# Altium schematic sheet Heracles Headers.SchDoc
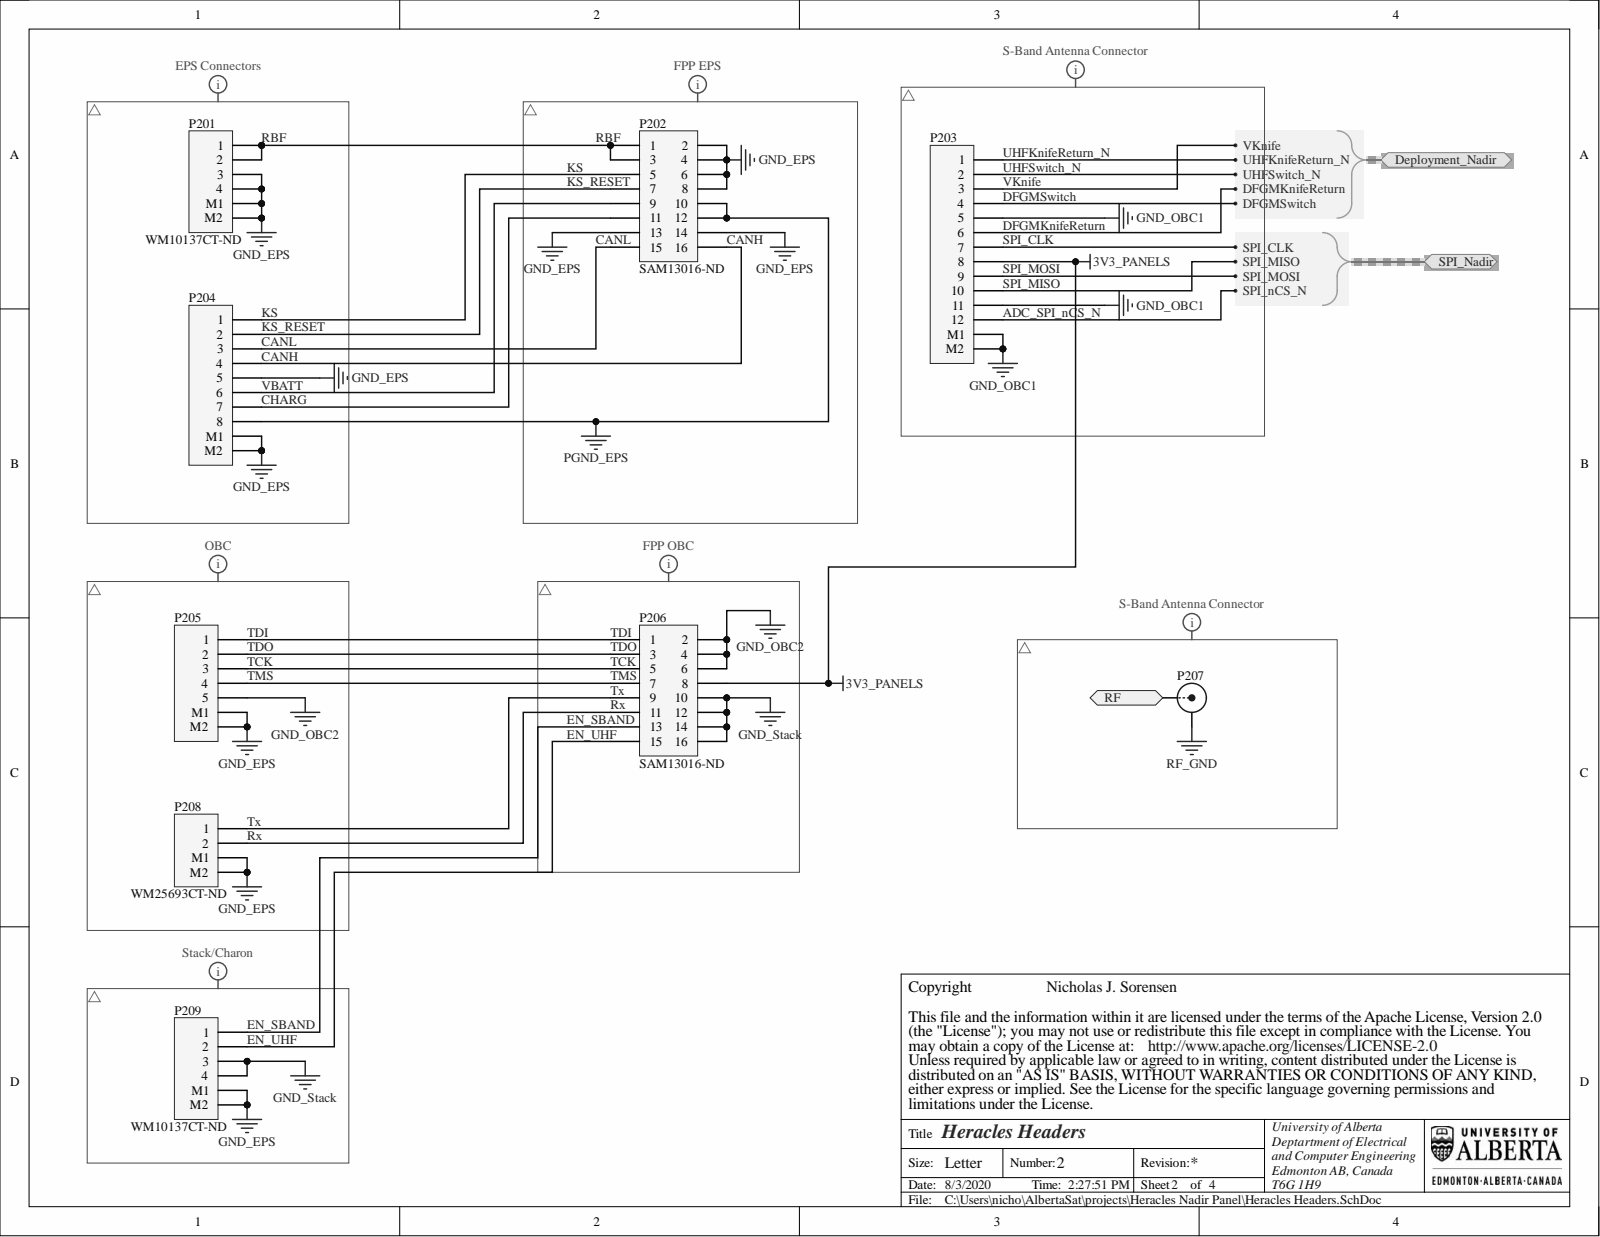
[diagram p0: Heracles Headers.SchDoc]

## Parts
P201  WM10137CT-ND  [MOLX-5040500491]
P202  SAM13016-ND  [TSS-108-01-G-D]
P203  PICOLOCK 12  [MOLX-5040501291]  (value not drawn)
P204  WM14421CT-ND  [MOLX-5040500891]  (value not drawn)
P205  PICOLOCK 5  [MOLX-5040500591]  (value not drawn)
P206  SAM13016-ND  [TSS-108-01-G-D]
P207  J10399-ND  [J10399-ND]  (value not drawn)
P208  WM25693CT-ND  [MOLX-5040500291]
P209  WM10137CT-ND  [MOLX-5040500491]

## Ports
Deployment_Nadir, Deployment_Nadir.DFGMKnifeReturn, Deployment_Nadir.DFGMSwitch, Deployment_Nadir.UHFKnifeReturn_N, Deployment_Nadir.UHFSwitch_N, Deployment_Nadir.VKnife, RF, SPI_Nadir, SPI_Nadir.SPI_CLK, SPI_Nadir.SPI_MISO, SPI_Nadir.SPI_MOSI, SPI_Nadir.SPI_nCS_N

## Nets
3V3_PANELS: P203.8 P206.8
GND_EPS: P201.3 P201.4 P201.M1 P201.M2 P202.2 P202.4 P202.6 P202.8 P202.13 P202.14 P204.5 P204.M1 P204.M2 P205.M1 P205.M2 P208.M1 P208.M2 P209.M1 P209.M2
GND_OBC1: P203.5 P203.11 P203.M1 P203.M2
GND_OBC2: P205.5 P206.2 P206.4 P206.6
GND_Stack: P206.10 P206.12 P206.14 P206.16 P209.3 P209.4
PGND_EPS: P202.10 P202.12 P204.8
RF_GND: P207.2
ADC_SPI_nCS_N: P203.12 ; port SPI_Nadir
CANH: P202.16 P204.4
CANL: P202.15 P204.3
CHARG: P202.11 P204.7
DFGMKnifeReturn: P203.6 ; port Deployment_Nadir
DFGMSwitch: P203.4 ; port Deployment_Nadir
EN_SBAND: P206.13 P209.1
EN_UHF: P206.15 P209.2
KS: P202.5 P204.1
KS_RESET: P202.7 P204.2
RBF: P201.1 P201.2 P202.1 P202.3
RF: P207.1 ; port RF
Rx: P206.11 P208.2
SPI_CLK: P203.7 ; port SPI_Nadir
SPI_MISO: P203.10 ; port SPI_Nadir
SPI_MOSI: P203.9 ; port SPI_Nadir
TCK: P205.3 P206.5
TDI: P205.1 P206.1
TDO: P205.2 P206.3
TMS: P205.4 P206.7
Tx: P206.9 P208.1
UHFKnifeReturn_N: P203.1 ; port Deployment_Nadir
UHFSwitch_N: P203.2 ; port Deployment_Nadir
VBATT: P202.9 P204.6
VKnife: P203.3 ; port Deployment_Nadir

## Library data of the parts
P201 P209 = 63: CONN HEADER SMD R/A 4POS 1.5MM; Manufacturer Part Number: 5040500491; Database Table Name: Molex; Component Kind: Standard; Designator: P?; LatestRevisionDate: 17-Jul-2002; LatestRevisionNote: Re-released for DXP Platform.; Library Name: Database.DbLib; Part Number: 63; Signal Integrity: Connector; Supplier 1: Digi-Key; Supplier Link: #https://www.digikey.ca/product-detail/en/molex/5040500491/WM10137CT-ND/4357653#; Supplier Part Number 1: WM10137CT-ND; Publisher: Altium Limited
P202 P206 = PP-SAM-00007: CONN HEADER VERT 16POS 2.54MM; Database Table Name: Samtec; Index: 8; Component Kind: Standard; LatestRevisionDate: 7/1/2020; LatestRevisionNote: ...; Library Name: Database.DbLib; Manufacturer Part Number: TSS-108-01-G-D; Part Number: PP-SAM-00007; Published: 7/1/2020; Signal Integrity: Connector; Supplier 1: Digi-key; Supplier Link: #https://www.digikey.ca/en/products/detail/samtec-inc/TSS-108-01-G-D/2345166#; Supplier Part Number 1: SAM13016-ND; Publisher: AlbertaSat
P203 = 71: CONN HEADER SMD R/A 12POS 1.5MM; Manufacturer Part Number: 5040501291; Database Table Name: Molex; Component Kind: Standard; Designator: P?; LatestRevisionDate: 17-Jul-2002; LatestRevisionNote: Re-released for DXP Platform.; Library Name: Database.DbLib; Part Number: 71; Signal Integrity: Connector; Supplier 1: Digi-Key; Supplier Link: #https://www.digikey.ca/product-detail/en/molex/5040501291/WM16219CT-ND/6702294#; Supplier Part Number 1: WM16219CT-ND; Publisher: Altium Limited
P204 = 67: CONN HEADER SMD R/A 8POS 1.5MM; Manufacturer Part Number: 5040500891; Database Table Name: Molex; Component Kind: Standard; Designator: P?; LatestRevisionDate: 17-Jul-2002; LatestRevisionNote: Re-released for DXP Platform.; Library Name: Database.DbLib; Part Number: 67; Signal Integrity: Connector; Supplier 1: Digi-Key; Supplier Link: #https://www.digikey.ca/product-detail/en/molex/5040500891/WM14421CT-ND/6133151#; Supplier Part Number 1: WM14421CT-ND; Publisher: Altium Limited
P205 = 64: CONN HEADER SMD R/A 5POS 1.5MM; Manufacturer Part Number: 5040500591; Database Table Name: Molex; Component Kind: Standard; Designator: P?; LatestRevisionDate: 17-Jul-2002; LatestRevisionNote: Re-released for DXP Platform.; Library Name: Database.DbLib; Part Number: 64; Signal Integrity: Connector; Supplier 1: Digi-Key; Supplier Link: https://www.digikey.ca/product-detail/en/molex/5040500591/WM24877CT-ND/9659635; Supplier Part Number 1: WM24877CT-ND; Publisher: Altium Limited
P207 = P01-CIN-00000: CONN SMB PLUG R/A 50OHM PCB; Manufacturer Part Number: Cinch Connectivity Solutions Johnson; Database Table Name: Cinch; Index: 1; Component Kind: Standard; Designator: P?; LatestRevisionDate: 7/6/2020; LatestRevisionNote: ...; Library Name: Database.DbLib; Part Number: P01-CIN-00000; Published: 7/6/2020; Signal Integrity: Connector; Supplier 1: Digi-key; Supplier Link: #https://www.digikey.ca/en/products/detail/cinch-connectivity-solutions-johnson/131-1801-371/251419#; Supplier Part Number 1: J10399-ND; Publisher: AlbertaSat
P208 = 61: CONN HEADER SMD R/A 2POS 1.5MM; Manufacturer Part Number: 5040500291; Database Table Name: Molex; Component Kind: Standard; Designator: P?; LatestRevisionDate: 17-Jul-2002; LatestRevisionNote: Re-released for DXP Platform.; Library Name: Database.DbLib; Part Number: 61; Signal Integrity: Connector; Supplier 1: Digi-Key; Supplier Link: #https://www.digikey.ca/product-detail/en/molex/5040500291/WM25693CT-ND/9954119#; Supplier Part Number 1: WM25693CT-ND; Publisher: Altium Limited
